# Altium schematic sheet LPC1343FBD48_revA.SchDoc(LPC1343FBD48_revA)
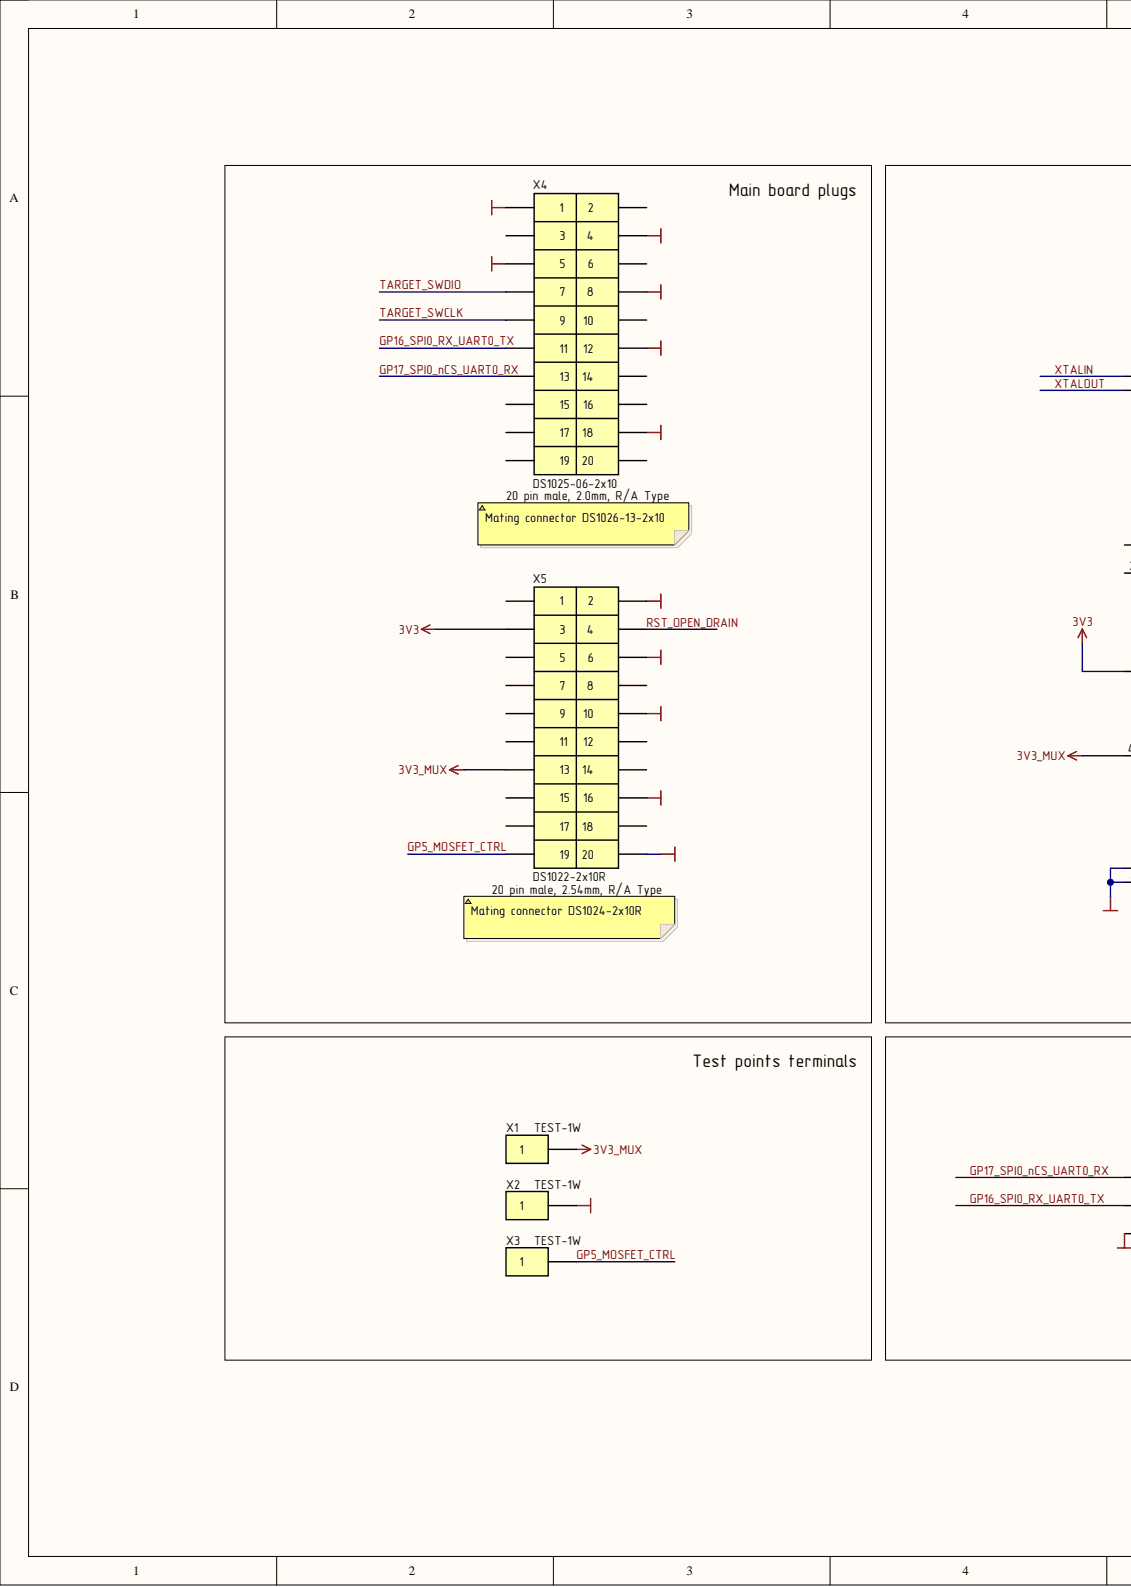
[diagram p0: LPC1343FBD48_revA.SchDoc, left]
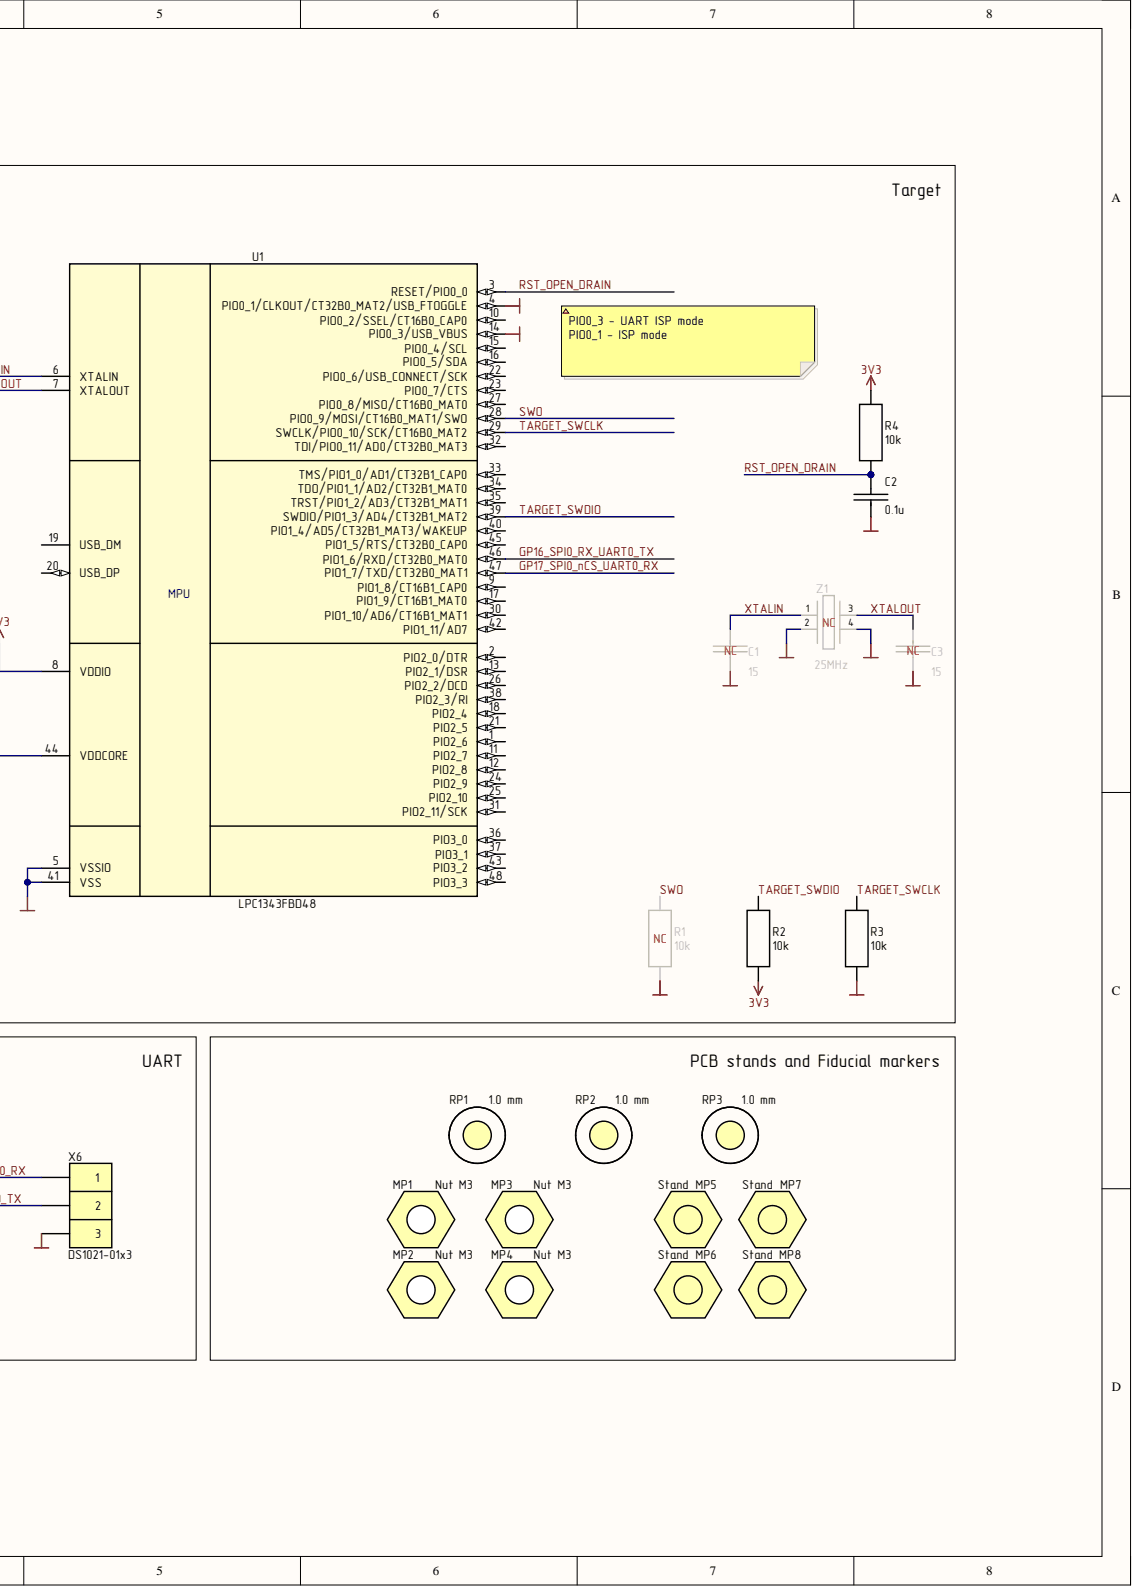
[diagram p1: LPC1343FBD48_revA.SchDoc, right]

## Parts
C1  value 15  [CH0603C]  (hidden comment: Ceramic capacitor, 0603, 15, ±10%, 100V, C0G, -55...+125°C)
C2  value 0.1u  [CH0603C]  (hidden comment: Ceramic capacitor, 0603, 0.1u, ±10%, 50V, X7R, -55...+125°C)
C3  value 15  [CH0603C]  (hidden comment: Ceramic capacitor, 0603, 15, ±10%, 100V, C0G, -55...+125°C)
MP1  Nut M3  [Stand]  (mechanical)
MP2  Nut M3  [Stand]  (mechanical)
MP3  Nut M3  [Stand]  (mechanical)
MP4  Nut M3  [Stand]  (mechanical)
MP5  Stand  [Stand]
MP6  Stand  [Stand]
MP7  Stand  [Stand]
MP8  Stand  [Stand]
R1  value 10k  [CH0603R]  (hidden comment: Resistor thick-film, 0603, 10k, ±5%, 0.1W, -55...+125°C)
R2  value 10k  [CH0603R]  (hidden comment: Resistor thick-film, 0603, 10k, ±5%, 0.1W, -55...+125°C)
R3  value 10k  [CH0603R]  (hidden comment: Resistor thick-film, 0603, 10k, ±5%, 0.1W, -55...+125°C)
R4  value 10k  [CH0603R]  (hidden comment: Resistor thick-film, 0603, 10k, ±5%, 0.1W, -55...+125°C)
RP1  1.0 mm  [REPER-10]  (no BOM)
RP2  1.0 mm  [REPER-10]  (no BOM)
RP3  1.0 mm  [REPER-10]  (no BOM)
U1  LPC1343FBD48  [LQFP48]
X1  3V3_MUX  PartNumber: TEST-1W  [TEST-1W 1pin MULTICOMP]
X2  PartNumber: TEST-1W  [TEST-1W 1pin MULTICOMP]  (hidden comment: GND)
X3  GP5  PartNumber: TEST-1W  [TEST-1W 1pin MULTICOMP]
X4  DS1025-06-2x10  [DS1025-06-2x10]
X5  DS1022-2x10R  [DS1022-2x10R]
X6  DS1021-01x3  [DS1021-01-3 2.54]
Z1  Frequency: 25MHz  [OSC: 3225]  (hidden comment: Crystal, SMD3225C4, 25MHz)

## Nets
3V3: R2.2 R4.2 U1.8(VDDIO) X5.3
3V3_MUX: U1.44(VDDCORE) X1.1 X5.13
GND: C1.2 C2.1 C3.2 R1.1 R3.1 U1.4 U1.5(VSSIO) U1.14 U1.41(VSS) X2.1 X4.1 X4.4 X4.5 X4.8 X4.12 X4.18 X5.2 X5.6 X5.10 X5.16 X5.20 X6.3 Z1.2 Z1.4(NC)
GP5_MOSFET_CTRL: X3.1 X5.19
GP16_SPI0_RX_UART0_TX: U1.46(PIO1_6/RXD/CT32B0_MAT0) X4.11 X6.2
GP17_SPI0_nCS_UART0_RX: U1.47(PIO1_7/TXD/CT32B0_MAT1) X4.13 X6.1
RST_OPEN_DRAIN: C2.2 R4.1 U1.3(RESET/PIO0_0) X5.4
SWO: R1.2 U1.28
TARGET_SWCLK: R3.2 U1.29(SWCLK/PIO0_10/SCK/CT16B0_MAT2) X4.9
TARGET_SWDIO: R2.1 U1.39(SWDIO/PIO1_3/AD4/CT32B1_MAT2) X4.7
XTALIN: C1.1 U1.6(XTALIN) Z1.1
XTALOUT: C3.1 U1.7(XTALOUT) Z1.3

Not connected: MP5 1; MP6 1; MP7 1; MP8 1; RP1 1; RP2 1; RP3 1; U1 1(PIO2_6) 2(PIO2_0/DTR) 9(PIO1_8/CT16B1_CAP0) 10 11(PIO2_7) 12(PIO2_8) 13(PIO2_1/DSR) 15 16 17(PIO1_9/CT16B1_MAT0) 18(PIO2_4) 19(USB_DM) 20(USB_DP) 21(PIO2_5) 22 23 24(PIO2_9) 25(PIO2_10) 26(PIO2_2/DCD) 27 30(PIO1_10/AD6/CT16B1_MAT1) 31(PIO2_11/SCK) 32(TDI/PIO0_11/AD0/CT32B0_MAT3) 33(TMS/PIO1_0/AD1/CT32B1_CAP0) 34(TDO/PIO1_1/AD2/CT32B1_MAT0) 35(TRST/PIO1_2/AD3/CT32B1_MAT1) 36(PIO3_0) 37(PIO3_1) 38(PIO2_3/RI) 40(PIO1_4/AD5/CT32B1_MAT3/WAKEUP) 42(PIO1_11/AD7) 43(PIO3_2) 45(PIO1_5/RTS/CT32B0_CAP0) 48(PIO3_3); X4 2 3 6 10 14 15 16 17 19 20; X5 1 5 7 8 9 11 12 14 15 17 18

## Library data of the parts
C1 C3 = Capacitor: Manufacturer: Murata; PartNumber: GRM1885C2A150J; Type: SMD; Component Kind: Standard; ComponentType: Ceramic capacitor; Description/Value: Ceramic capacitor, 0603, 15, ±10%, 100V, C0G, -55...+125°C; HelpURL: ?; Library Name: Common Lib.SchLib; Package: 0603; Temperature: -55...+125°C; Tolerance: ±10%; TypeDielectric: C0G; Voltage: 100V
C2 = Capacitor: Manufacturer: Murata; PartNumber: GRM188R71H104K; Type: SMD; Component Kind: Standard; ComponentType: Ceramic capacitor; Description/Value: Ceramic capacitor, 0603, 0.1u, ±10%, 50V, X7R, -55...+125°C; HelpURL: ?; Library Name: Common Lib.SchLib; Package: 0603; Temperature: -55...+125°C; Tolerance: ±10%; TypeDielectric: X7R; Voltage: 50V
MP1-MP4 = nut: PartNumber: Nut M3 DIN 934; Description/Value: Hexagon Nut M3 DIN 934; Library Name: Common Lib.SchLib
MP5-MP8 = stand: PartNumber: PCHSN-10; Description/Value: PCB brass stand, M3, 10mm; Component Kind: Standard; Library Name: Common Lib.SchLib
R1-R4 = Resistor: PartNumber: RC0603JR-0710KL; Component Kind: Standard; ComponentType: Resistor thick-film; Description/Value: Resistor thick-film, 0603, 10k, ±5%, 0.1W, -55...+125°C; Library Name: Common Lib.SchLib; Package: 0603; Power: 0.1W; Temperature: -55...+125°C; Tolerance: ±5%
RP1-RP3 = CMP-00005-2: Design Item ID: REPER; Source: Common Lib.SchLib; Library Name: Positive Labs Server
U1 = LPC1343FBD48: ARM Cortex-M3 LPC13xx Microcontroller IC 32-Bit 72MHz 32KB (32K x 8) FLASH 48-LQFP (7x7); Description/Value: Microcontroller LPC1343FBD48, LQFP-48; Component Kind: Standard; Library Name: Common Lib.SchLib; PartNumber: LPC1343FBD48
X1-X3 = 1-Connector: Description/Value: PCB Test Point Through Hole Mount; Component Kind: Standard; Library Name: Common Lib.SchLib
X4 = 20-Connector: Description/Value: PLD Connector 20 pin, 2.0mm, Male, DS1025-06-2x10; Component Kind: Standard; ComponentKind: PLD Connector 20 pin, 2.0mm, Male; Library Name: Common Lib.SchLib; PartNumber: DS1025-06-2x10
X5 = 20-Connector: Description/Value: PLD Connector 20 pin, 2.54mm, Male, DS1022-2x10R; Component Kind: Standard; ComponentKind: PLD Connector 20 pin, 2.54mm, Male; Library Name: Common Lib.SchLib; PartNumber: DS1022-2x10R
X6 = CMP-00065-2: PLS Connector 3 pin, 2.54mm, Male; Component Kind: Standard; Description/Value: PLS Connector 3 pin, 2.54mm, Male; Design Item ID: 3-Connector; PartNumber: DS1021-01x3; Source: Common Lib.SchLib; Library Name: Positive Labs Server
Z1 = Crystal_3225_25MHz: PartNumber: NX3225GA-25MHZ; Component Kind: Standard; Description/Value: Crystal, SMD3225C4, 25MHz; Library Name: Common Lib.SchLib
